# Altium schematic sheet StepperDrivers.SchDoc(StepperDrivers)
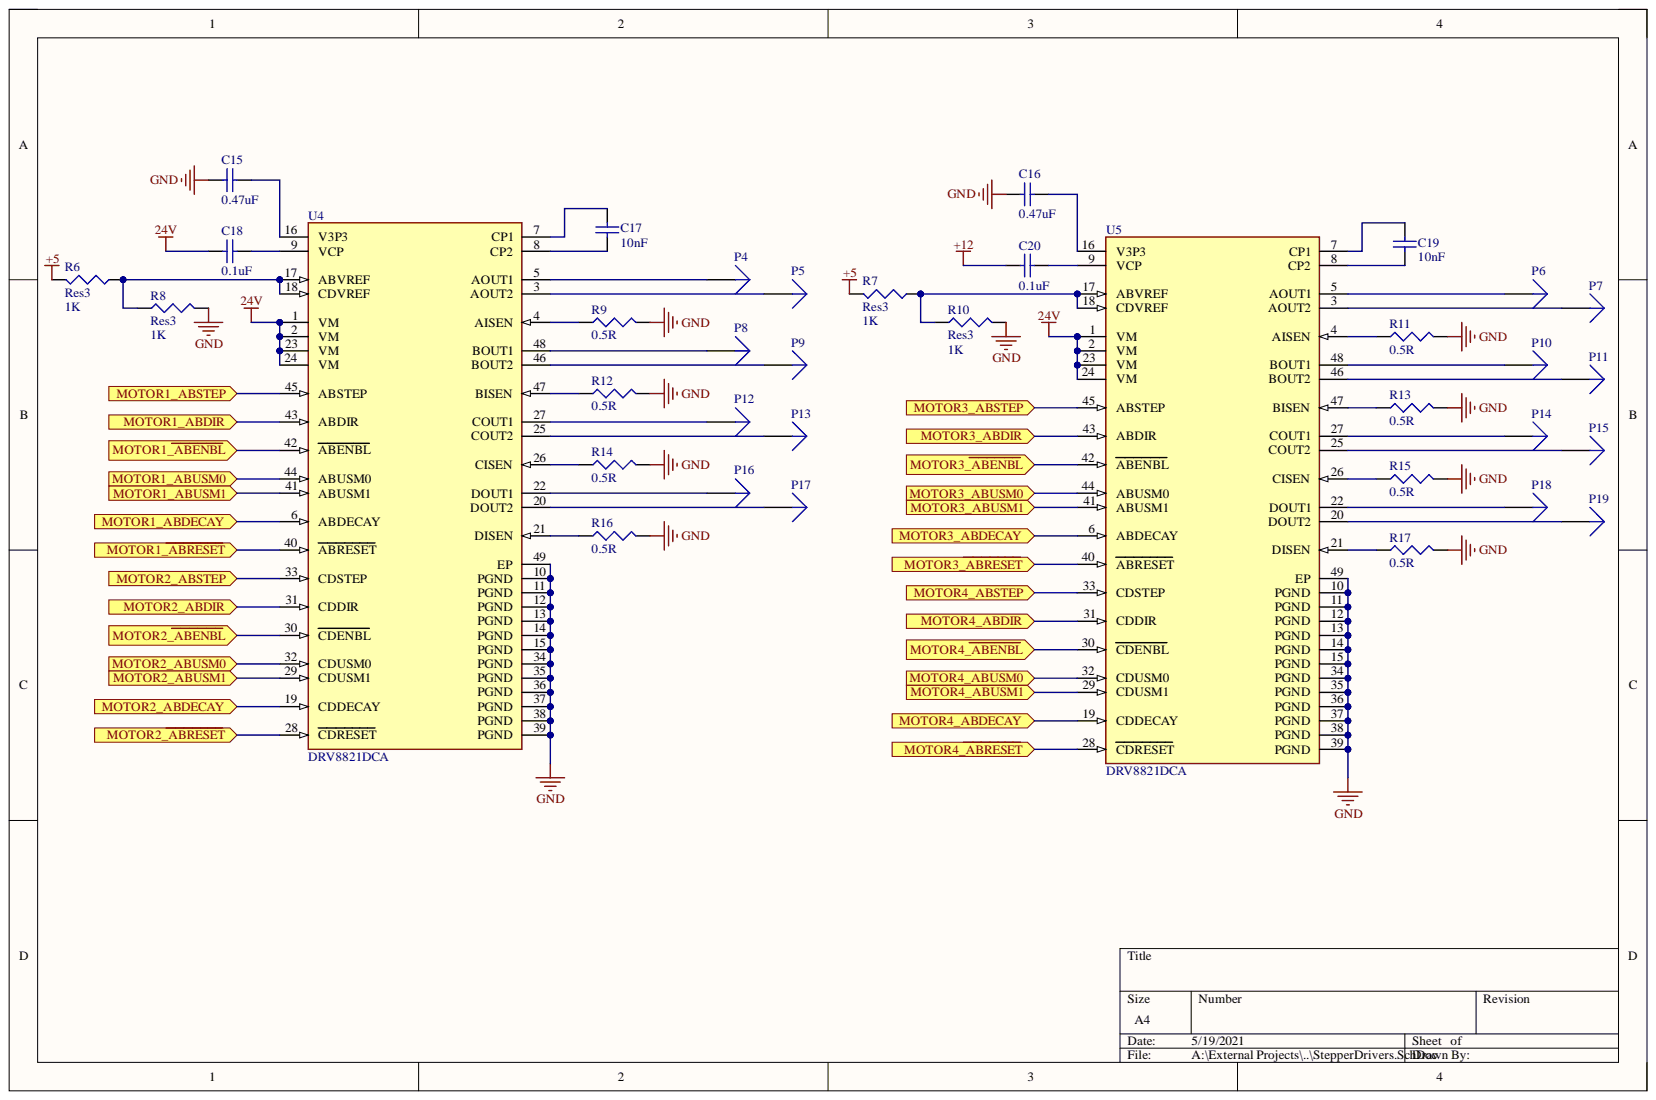
[diagram p0: StepperDrivers.SchDoc]

## Parts
C15  value 0.47uF  [C1206]  (hidden comment: Cap Semi)
C16  value 0.47uF  [C1206]  (hidden comment: Cap Semi)
C17  value 10nF  [C1206]  (hidden comment: Cap Semi)
C18  value 0.1uF  [C1206]  (hidden comment: Cap Semi)
C19  value 10nF  [C1206]  (hidden comment: Cap Semi)
C20  value 0.1uF  [C1206]  (hidden comment: Cap Semi)
P4  Plug  [PIN1]  (value not drawn)
P5  Plug  [PIN1]  (value not drawn)
P6  Plug  [PIN1]  (value not drawn)
P7  Plug  [PIN1]  (value not drawn)
P8  Plug  [PIN1]  (value not drawn)
P9  Plug  [PIN1]  (value not drawn)
P10  Plug  [PIN1]  (value not drawn)
P11  Plug  [PIN1]  (value not drawn)
P12  Plug  [PIN1]  (value not drawn)
P13  Plug  [PIN1]  (value not drawn)
P14  Plug  [PIN1]  (value not drawn)
P15  Plug  [PIN1]  (value not drawn)
P16  Plug  [PIN1]  (value not drawn)
P17  Plug  [PIN1]  (value not drawn)
P18  Plug  [PIN1]  (value not drawn)
P19  Plug  [PIN1]  (value not drawn)
R6  Res3  value 1K  [J1-0603]
R7  Res3  value 1K  [J1-0603]
R8  Res3  value 1K  [J1-0603]
R9  value 0.5R  [RESC6332]  (hidden comment: Res3)
R10  Res3  value 1K  [J1-0603]
R11  value 0.5R  [RESC6332]  (hidden comment: Res3)
R12  value 0.5R  [RESC6332]  (hidden comment: Res3)
R13  value 0.5R  [RESC6332]  (hidden comment: Res3)
R14  value 0.5R  [RESC6332]  (hidden comment: Res3)
R15  value 0.5R  [RESC6332]  (hidden comment: Res3)
R16  value 0.5R  [RESC6332]  (hidden comment: Res3)
R17  value 0.5R  [RESC6332]  (hidden comment: Res3)
U4  DRV8821DCA  [FP-DCA0048E-MFG]
U5  DRV8821DCA  [FP-DCA0048E-MFG]

## Ports
MOTOR1_ABDECAY, MOTOR1_ABDIR, MOTOR1_ABSTEP, MOTOR1_ABUSM0, MOTOR1_ABUSM1, MOTOR1_~{ABENBL}, MOTOR1_~{ABRESET}, MOTOR2_ABDECAY, MOTOR2_ABDIR, MOTOR2_ABSTEP, MOTOR2_ABUSM0, MOTOR2_ABUSM1, MOTOR2_~{ABENBL}, MOTOR2_~{ABRESET}, MOTOR3_ABDECAY, MOTOR3_ABDIR, MOTOR3_ABSTEP, MOTOR3_ABUSM0, MOTOR3_ABUSM1, MOTOR3_~{ABENBL}, MOTOR3_~{ABRESET}, MOTOR4_ABDECAY, MOTOR4_ABDIR, MOTOR4_ABSTEP, MOTOR4_ABUSM0, MOTOR4_ABUSM1, MOTOR4_~{ABENBL}, MOTOR4_~{ABRESET}

## Nets
24V: C18.1 U4.1(VM) U4.2(VM) U4.23(VM) U4.24(VM) U5.1(VM) U5.2(VM) U5.23(VM) U5.24(VM)
+5: R6.2 R7.2
+12: C20.1
GND: C15.1 C16.1 R8.2 R9.2 R10.2 R11.2 R12.2 R13.2 R14.2 R15.2 R16.2 R17.2 U4.10(PGND) U4.11(PGND) U4.12(PGND) U4.13(PGND) U4.14(PGND) U4.15(PGND) U4.34(PGND) U4.35(PGND) U4.36(PGND) U4.37(PGND) U4.38(PGND) U4.39(PGND) U4.49(EP) U5.10(PGND) U5.11(PGND) U5.12(PGND) U5.13(PGND) U5.14(PGND) U5.15(PGND) U5.34(PGND) U5.35(PGND) U5.36(PGND) U5.37(PGND) U5.38(PGND) U5.39(PGND) U5.49(EP)
MOTOR1_~{ABENBL}: U4.42(ABENBL) ; port MOTOR1_~{ABENBL}
MOTOR1_~{ABRESET}: U4.40(ABRESET) ; port MOTOR1_~{ABRESET}
MOTOR1_ABDECAY: U4.6(ABDECAY) ; port MOTOR1_ABDECAY
MOTOR1_ABDIR: U4.43(ABDIR) ; port MOTOR1_ABDIR
MOTOR1_ABSTEP: U4.45(ABSTEP) ; port MOTOR1_ABSTEP
MOTOR1_ABUSM0: U4.44(ABUSM0) ; port MOTOR1_ABUSM0
MOTOR1_ABUSM1: U4.41(ABUSM1) ; port MOTOR1_ABUSM1
MOTOR2_~{ABENBL}: U4.30(CDENBL) ; port MOTOR2_~{ABENBL}
MOTOR2_~{ABRESET}: U4.28(CDRESET) ; port MOTOR2_~{ABRESET}
MOTOR2_ABDECAY: U4.19(CDDECAY) ; port MOTOR2_ABDECAY
MOTOR2_ABDIR: U4.31(CDDIR) ; port MOTOR2_ABDIR
MOTOR2_ABSTEP: U4.33(CDSTEP) ; port MOTOR2_ABSTEP
MOTOR2_ABUSM0: U4.32(CDUSM0) ; port MOTOR2_ABUSM0
MOTOR2_ABUSM1: U4.29(CDUSM1) ; port MOTOR2_ABUSM1
MOTOR3_~{ABENBL}: U5.42(ABENBL) ; port MOTOR3_~{ABENBL}
MOTOR3_~{ABRESET}: U5.40(ABRESET) ; port MOTOR3_~{ABRESET}
MOTOR3_ABDECAY: U5.6(ABDECAY) ; port MOTOR3_ABDECAY
MOTOR3_ABDIR: U5.43(ABDIR) ; port MOTOR3_ABDIR
MOTOR3_ABSTEP: U5.45(ABSTEP) ; port MOTOR3_ABSTEP
MOTOR3_ABUSM0: U5.44(ABUSM0) ; port MOTOR3_ABUSM0
MOTOR3_ABUSM1: U5.41(ABUSM1) ; port MOTOR3_ABUSM1
MOTOR4_~{ABENBL}: U5.30(CDENBL) ; port MOTOR4_~{ABENBL}
MOTOR4_~{ABRESET}: U5.28(CDRESET) ; port MOTOR4_~{ABRESET}
MOTOR4_ABDECAY: U5.19(CDDECAY) ; port MOTOR4_ABDECAY
MOTOR4_ABDIR: U5.31(CDDIR) ; port MOTOR4_ABDIR
MOTOR4_ABSTEP: U5.33(CDSTEP) ; port MOTOR4_ABSTEP
MOTOR4_ABUSM0: U5.32(CDUSM0) ; port MOTOR4_ABUSM0
MOTOR4_ABUSM1: U5.29(CDUSM1) ; port MOTOR4_ABUSM1
NetC15_2: C15.2 U4.16(V3P3)
NetC16_2: C16.2 U5.16(V3P3)
NetC17_1: C17.1 U4.8(CP2)
NetC17_2: C17.2 U4.7(CP1)
NetC18_2: C18.2 U4.9(VCP)
NetC19_1: C19.1 U5.8(CP2)
NetC19_2: C19.2 U5.7(CP1)
NetC20_2: C20.2 U5.9(VCP)
NetP4_1: P4.1 U4.5(AOUT1)
NetP5_1: P5.1 U4.3(AOUT2)
NetP6_1: P6.1 U5.5(AOUT1)
NetP7_1: P7.1 U5.3(AOUT2)
NetP8_1: P8.1 U4.48(BOUT1)
NetP9_1: P9.1 U4.46(BOUT2)
NetP10_1: P10.1 U5.48(BOUT1)
NetP11_1: P11.1 U5.46(BOUT2)
NetP12_1: P12.1 U4.27(COUT1)
NetP13_1: P13.1 U4.25(COUT2)
NetP14_1: P14.1 U5.27(COUT1)
NetP15_1: P15.1 U5.25(COUT2)
NetP16_1: P16.1 U4.22(DOUT1)
NetP17_1: P17.1 U4.20(DOUT2)
NetP18_1: P18.1 U5.22(DOUT1)
NetP19_1: P19.1 U5.20(DOUT2)
NetR6_1: R6.1 R8.1 U4.17(ABVREF) U4.18(CDVREF)
NetR7_1: R7.1 R10.1 U5.17(ABVREF) U5.18(CDVREF)
NetR9_1: R9.1 U4.4(AISEN)
NetR11_1: R11.1 U5.4(AISEN)
NetR12_1: R12.1 U4.47(BISEN)
NetR13_1: R13.1 U5.47(BISEN)
NetR14_1: R14.1 U4.26(CISEN)
NetR15_1: R15.1 U5.26(CISEN)
NetR16_1: R16.1 U4.21(DISEN)
NetR17_1: R17.1 U5.21(DISEN)

## Library data of the parts
C15-C20 = Cap Semi: Capacitor (Semiconductor SIM Model); Component Kind: Standard; LatestRevisionDate: 29-May-2009; LatestRevisionNote: IPC-7351 Footprint Added.; Library Name: Miscellaneous Devices.IntLib; PackageDescription: Chip Capacitor; PackageReference: C1206; Published: 8-Jun-2000; Signal Integrity: Cap Semi; Simulation: CAP; Publisher: Altium Limited
R6-R17 = Res3: Resistor; Component Kind: Standard; LatestRevisionDate: 29-May-2009; LatestRevisionNote: IPC-7351 Footprint Added.; Library Name: Miscellaneous Devices.IntLib; PackageDescription: Chip Resistor; PackageReference: J1-0603; Published: 8-Jun-2000; Signal Integrity: Res; Simulation: RESISTOR; Publisher: Altium Limited
U4 U5 = CMP-1556-00002-3: IC MTR DRV BIPOLR 8-32V 48HTSSOP; Collector Emitter Voltage (VCEO): 3.4V; Logic Function: AND GATE; Max Collector Current: 1.5A; Max Supply Voltage: 32V; Min Supply Voltage: 8V; Motor Type: Stepper; Nominal Supply Current: 5mA; Number of Outputs: 8; RMS Current (Irms): 1A; Switching Frequency: 50kHz; Case/Package: HTSSOP EP; Component Kind: Standard; Height: 1.05mm; Lead Free: Lead Free; Length: 12.6mm; Library Name: Altium Content Vault; Max Operating Temperature: 85°C; Max Output Current: 1.5A; Min Operating Temperature: -40°C; Number of Pins: 48; Output Current: 1.5A; Package Quantity: 40; Packaging: Rail/Tube; Radiation Hardening: No; REACH SVHC: No SVHC; RoHS Compliant: Yes; Width: 6.2mm
P4-P19 = Plug: Component Kind: Standard; Description: Plug; LatestRevisionDate: 17-Jul-2002; LatestRevisionNote: Re-released for DXP Platform.; Library Name: Miscellaneous Connectors.IntLib; Signal Integrity: Connector; Publisher: Altium Limited
